# Altium schematic sheet InputStage.SchDoc(InputStage)
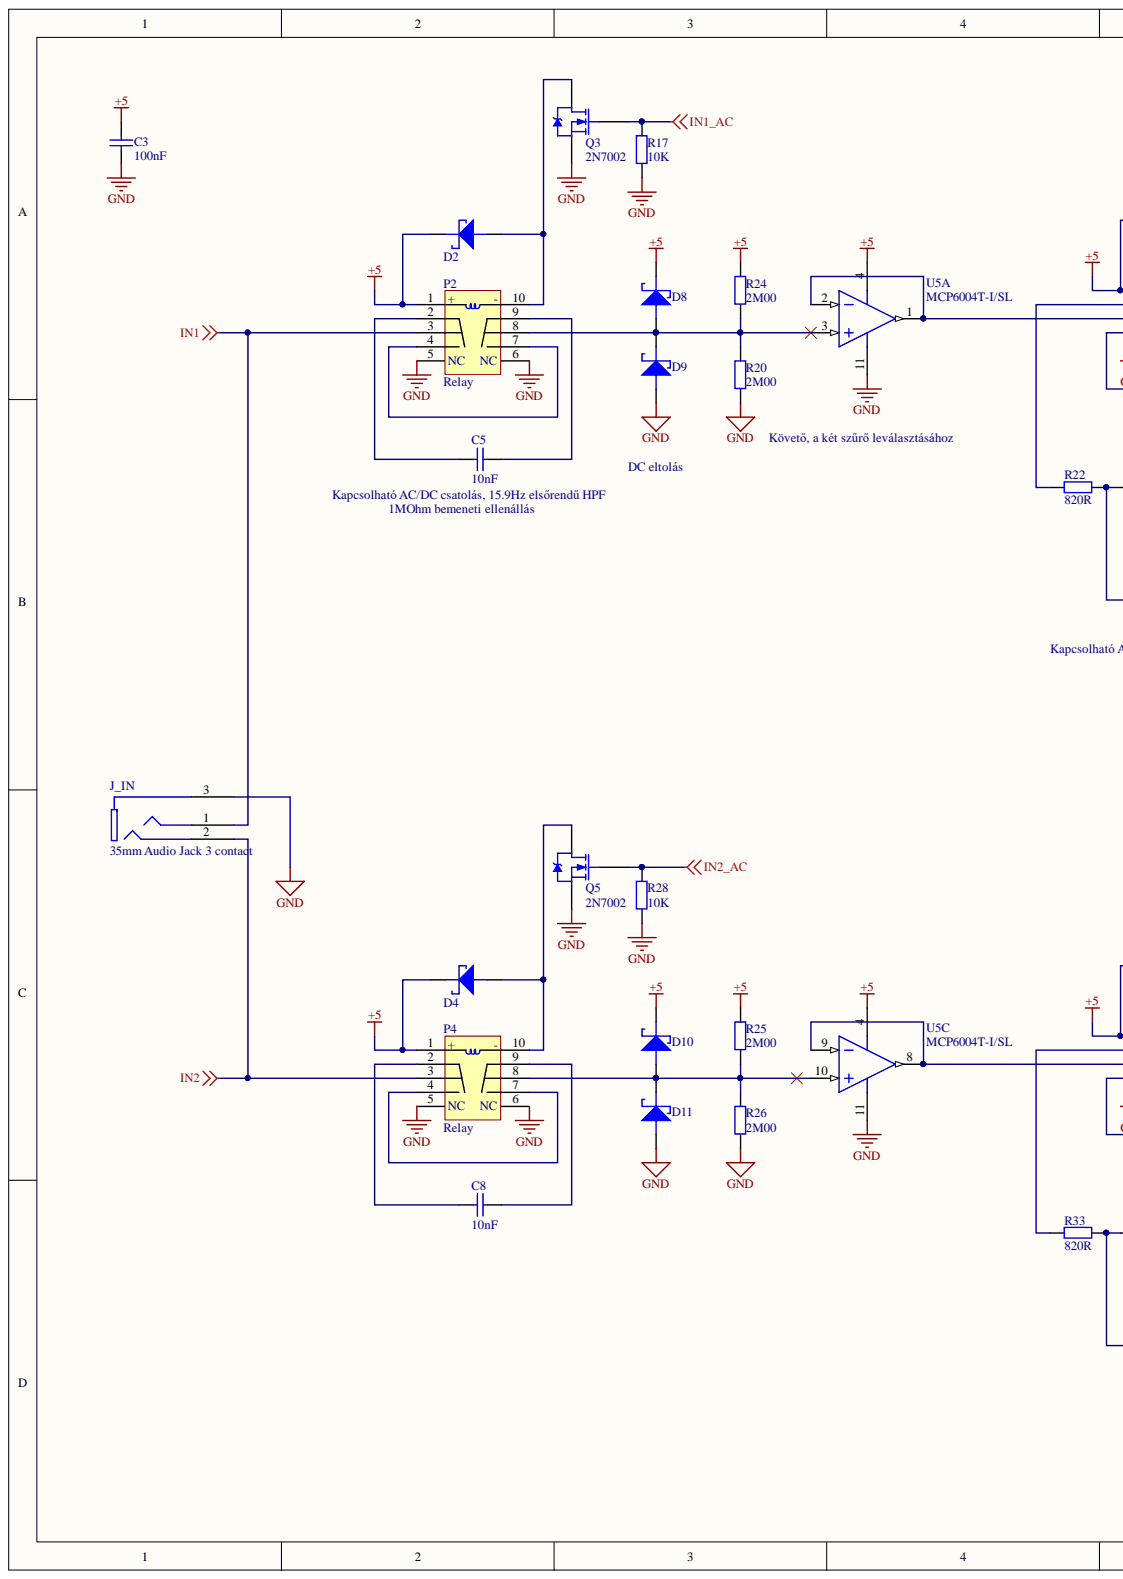
[diagram p0: InputStage.SchDoc, left]
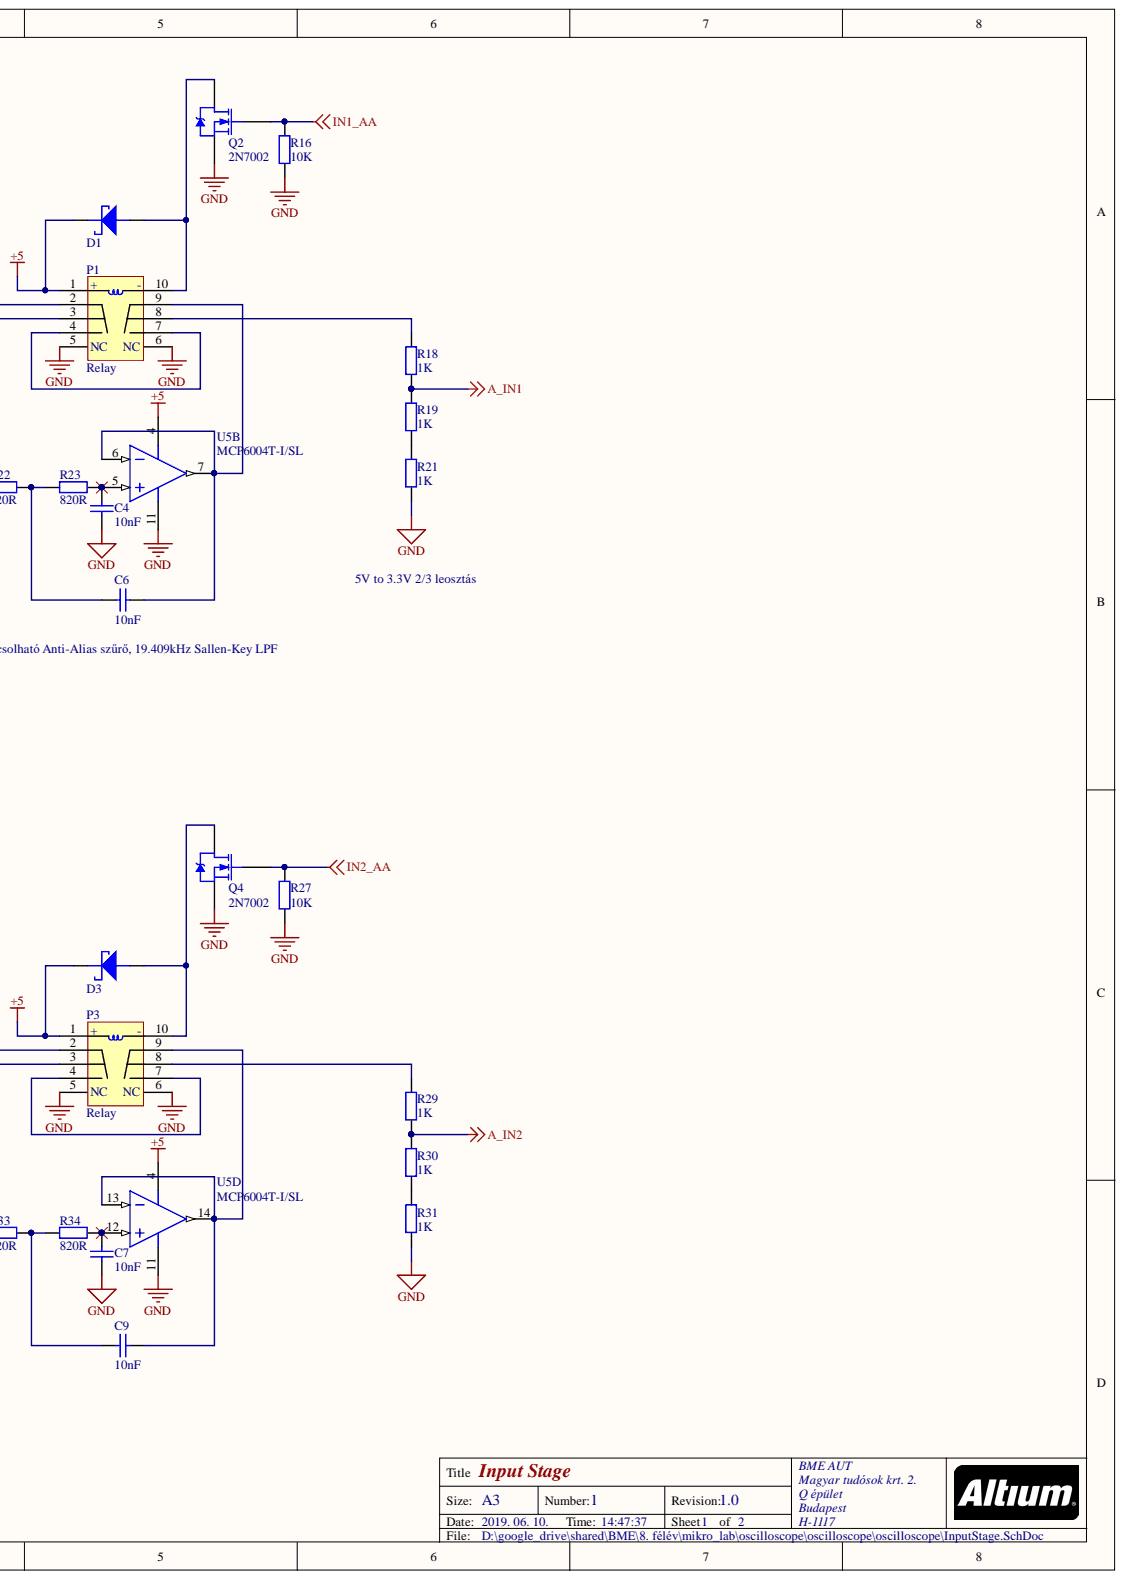
[diagram p1: InputStage.SchDoc, right]

## Parts
C3  100nF  [FP_0805_CAP_085]
C4  10nF  [FP_0805_CAP_085]
C5  10nF  [FP_0805_CAP_085]
C6  10nF  [FP_0805_CAP_085]
C7  10nF  [FP_0805_CAP_085]
C8  10nF  [FP_0805_CAP_085]
C9  10nF  [FP_0805_CAP_085]
D1  SCHOTTKY SMA  [FP_SMA]  (value not drawn)
D2  SCHOTTKY SMA  [FP_SMA]  (value not drawn)
D3  SCHOTTKY SMA  [FP_SMA]  (value not drawn)
D4  SCHOTTKY SMA  [FP_SMA]  (value not drawn)
D8  SCHOTTKY SMA  [FP_SMA]  (value not drawn)
D9  SCHOTTKY SMA  [FP_SMA]  (value not drawn)
D10  SCHOTTKY SMA  [FP_SMA]  (value not drawn)
D11  SCHOTTKY SMA  [FP_SMA]  (value not drawn)
J_IN  35mm Audio Jack 3 contact  [FP_35MM_JACK_SMALL_3PIN]
P1  Relay  [FP_RELAY]
P2  Relay  [FP_RELAY]
P3  Relay  [FP_RELAY]
P4  Relay  [FP_RELAY]
Q2  2N7002  [FP_SOT23-3]
Q3  2N7002  [FP_SOT23-3]
Q4  2N7002  [FP_SOT23-3]
Q5  2N7002  [FP_SOT23-3]
R16  10K  [FP_0805_RES]
R17  10K  [FP_0805_RES]
R18  1K  [FP_0805_RES]
R19  1K  [FP_0805_RES]
R20  2M00  [FP_0805_RES]
R21  1K  [FP_0805_RES]
R22  820R  [FP_0805_RES]
R23  820R  [FP_0805_RES]
R24  2M00  [FP_0805_RES]
R25  2M00  [FP_0805_RES]
R26  2M00  [FP_0805_RES]
R27  10K  [FP_0805_RES]
R28  10K  [FP_0805_RES]
R29  1K  [FP_0805_RES]
R30  1K  [FP_0805_RES]
R31  1K  [FP_0805_RES]
R33  820R  [FP_0805_RES]
R34  820R  [FP_0805_RES]
U5  MCP6004T-I/SL  [FP_SOIC127P600X175-14M]  (parts A,B,C,D)

## Nets
+5: C3.2 D1.1 D2.1 D3.1 D4.1 D8.1 D10.1 P1.1(+) P2.1(+) P3.1(+) P4.1(+) R24.2 R25.2 U5.4
A_IN1: R18.1 R19.2
A_IN2: R29.1 R30.2
GND: C3.1 C4.1 C7.1 D9.2 D11.2 J_IN.3 P1.5(NC) P1.6(NC) P2.5(NC) P2.6(NC) P3.5(NC) P3.6(NC) P4.5(NC) P4.6(NC) Q2.3 Q3.3 Q4.3 Q5.3 R16.2 R17.1 R20.1 R21.1 R26.1 R27.2 R28.2 R31.1 U5.11
IN1: J_IN.1 P2.3
IN1_AA: Q2.1 R16.1
IN1_AC: Q3.1 R17.2
IN2: J_IN.2 P4.3
IN2_AA: Q4.1 R27.1
IN2_AC: Q5.1 R28.1
NetC4_2: C4.2 R23.2 U5.5
NetC5_1: C5.1 P2.2
NetC5_2: C5.2 P2.9
NetC6_1: C6.1 R22.2 R23.1
NetC6_2: C6.2 P1.9 U5.6 U5.7
NetC7_2: C7.2 R34.2 U5.12
NetC8_1: C8.1 P4.2
NetC8_2: C8.2 P4.9
NetC9_1: C9.1 R33.2 R34.1
NetC9_2: C9.2 P3.9 U5.13 U5.14
NetD1_2: D1.2 P1.10(-) Q2.2
NetD2_2: D2.2 P2.10(-) Q3.2
NetD3_2: D3.2 P3.10(-) Q4.2
NetD4_2: D4.2 P4.10(-) Q5.2
NetD8_2: D8.2 D9.1 P2.8 R20.2 R24.1 U5.3
NetD10_2: D10.2 D11.1 P4.8 R25.1 R26.2 U5.10
NetP1_2: P1.2 R22.1
NetP1_3: P1.3 U5.1 U5.2
NetP1_4: P1.4 P1.7
NetP1_8: P1.8 R18.2
NetP2_4: P2.4 P2.7
NetP3_2: P3.2 R33.1
NetP3_3: P3.3 U5.8 U5.9
NetP3_4: P3.4 P3.7
NetP3_8: P3.8 R29.2
NetP4_4: P4.4 P4.7
NetR19_1: R19.1 R21.2
NetR30_1: R30.1 R31.2

## Library data of the parts
C3 = CAP 100nF: Capacitor; Voltage: 50V; Tolerance: 10%; Name: 100nF 0805 10% 50V X7R 0805B104K500CT (WAL); Value: 100nF; Supplier: Lomex; Reference: 82-10-76; Component Kind: Standard; Library Name: hallglib.SchLib
C4-C9 = CAP 10nF: Capacitor; Voltage: 100V; Tolerance: 10%; Name: 10nF 0805 10% 100V X7R 08051C103KAT2A (AVX); Value: 10nF; Supplier: Lomex; Reference: 82-11-02; Component Kind: Standard; Library Name: hallglib.SchLib
D1-D4 D8-D11 = SCHOTTKY SMA: Schottky diode; Voltage: 40V; Name: 1A 40V DO-214AC SCH.RECT.LOW Vf SSL14 (TSC) SMA; Current: 1A; Supplier: Lomex; Reference: 83-02-20; Component Kind: Standard; Library Name: hallglib.SchLib
J_IN = 35mm Audio Jack 3 contact: 3.5mm Audio Jack MX-387GL; Reference: 1267376; Name: MX-387GL -  Phone Audio Connector, 3 Contacts, Jack, 3.5 mm, PCB Mount, Metal Body; Supplier: Farnell; Component Kind: Standard; Library Name: hallglib.SchLib
P1-P4 = Relay Mini DPDT: Mini DPDT relay 14x9x5mm; Name: MECH 5V 2xMORSE 1A/30VDC 0.5A/12VAC A5W-K (TAK) 178R 14x9x5mm; Supplier: Lomex; Reference: 42-17-08; Component Kind: Standard; Library Name: hallglib.SchLib
Q2-Q5 = 2N7002: N-channel MOSFET; Voltage: 60V; Name: MOSFET Transistor, N Channel, 300mA, 60V, 2.8 ohm, 10V, 2V; Current: 300mA; Supplier: Lomex; Reference: 86-05-52; Component Kind: Standard; Library Name: hallglib.SchLib
R16 R17 R27 R28 = RES 10K: Resistor; Tolerance: 5%; Power: 125mW; Name: 10K0 0805 5% CR-05JL7---10K 0.125W (VIK); Value: 10K; Supplier: Lomex; Reference: 81-30-45; Component Kind: Standard; Library Name: hallglib.SchLib
R18 R19 R21 R29-R31 = RES 1K: Resistor; Tolerance: 5%; Power: 125mW; Name: 1K00 0805 5% WR08X102JTL 0.125W (WAL); Value: 1K; Supplier: Lomex; Reference: 81-27-93; Component Kind: Standard; Library Name: hallglib.SchLib
R20 R24-R26 = RES 2M: Resistor; Tolerance: 1%; Power: 125mW; Name: 2M00 0805 1% CR-05FL7---2M0 0.125W (VIK); Value: 2M00; Supplier: Lomex; Reference: 80-17-98; Component Kind: Standard; Library Name: hallglib.SchLib
R22 R23 R33 R34 = RES 820R: Resistor; Tolerance: 5%; Power: 125mW; Name: 820R 0805 5% WR08X821JTL 0.125W (WAL); Value: 820R; Supplier: Lomex; Reference: 81-30-21; Component Kind: Standard; Library Name: hallglib.SchLib
U5 = MCP6004T-I/SL: Operational Amplifier, Quad, 4 Amplifier, 1 MHz, 0.6 V/µs, 1.8V to 5.5V, SOIC, 14 Pins; Reference: 1627186; Name: MCP6004T-I/SL - Operational Amplifier; Supplier: Farnell; Component Kind: Standard; Library Name: hallglib.SchLib
